# Altium schematic sheet 6.232-485-CAN-DB.SchDoc(6.232-485-CAN-DB)
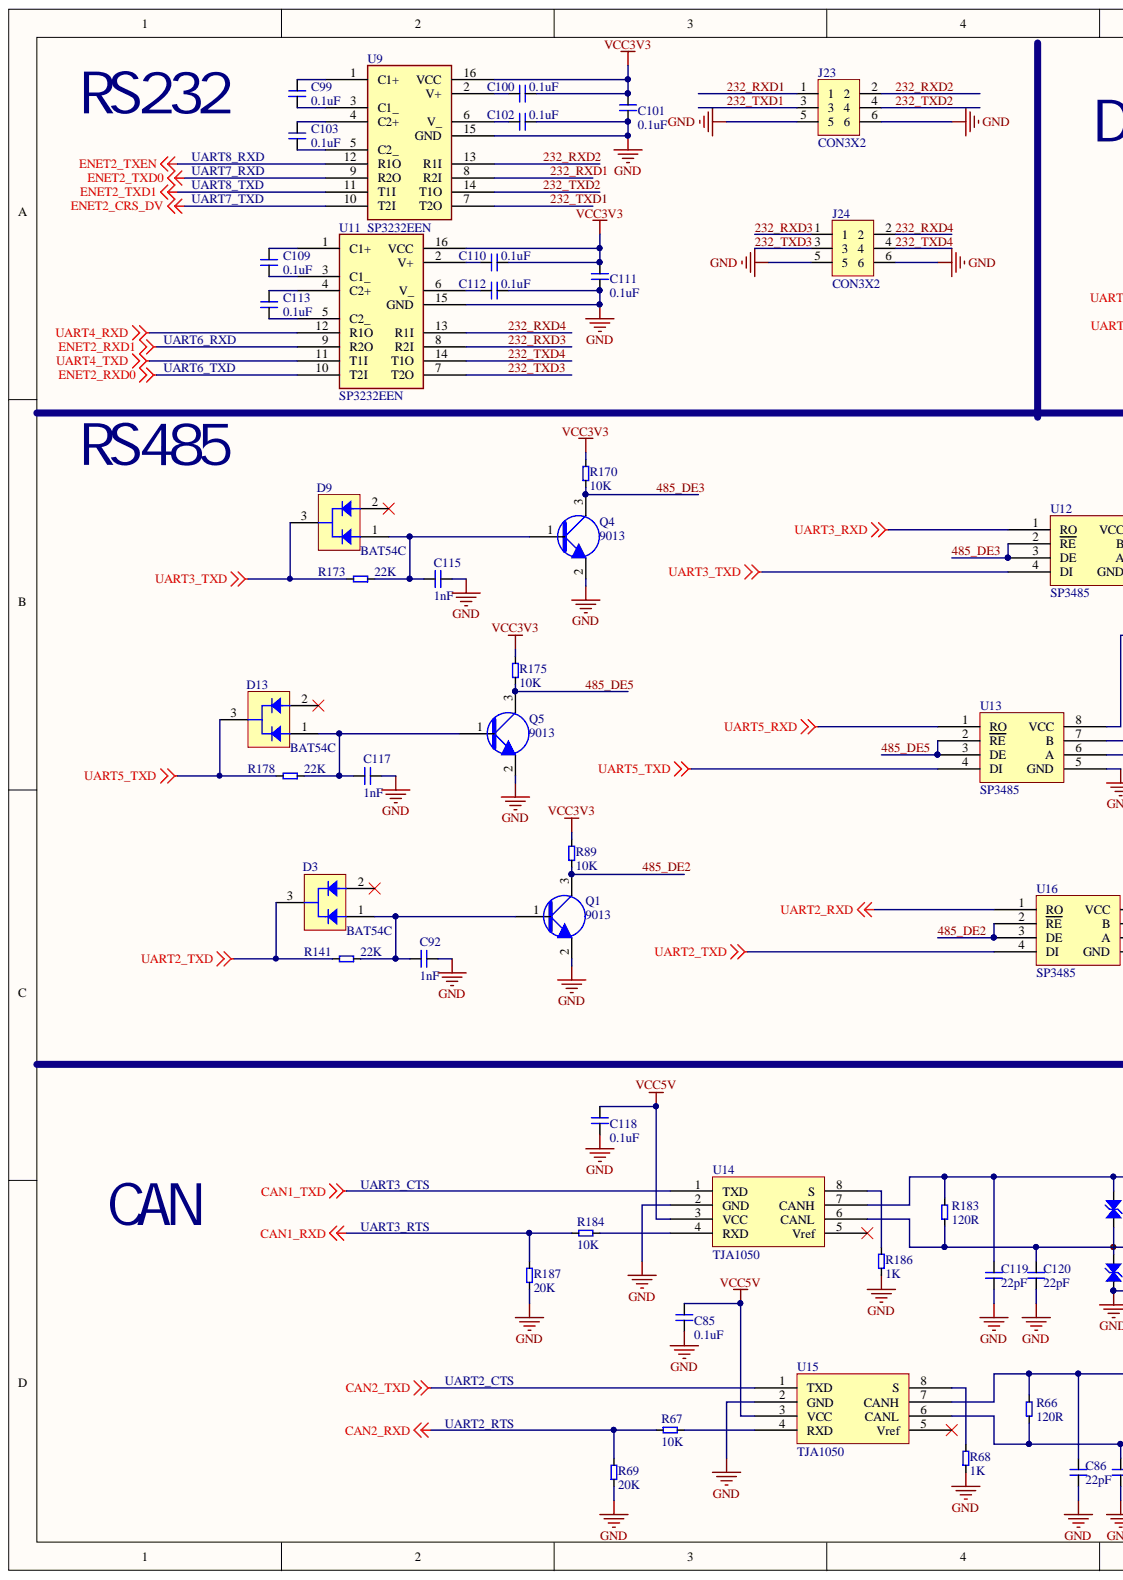
[diagram p0: 6.232-485-CAN-DB.SchDoc, left]
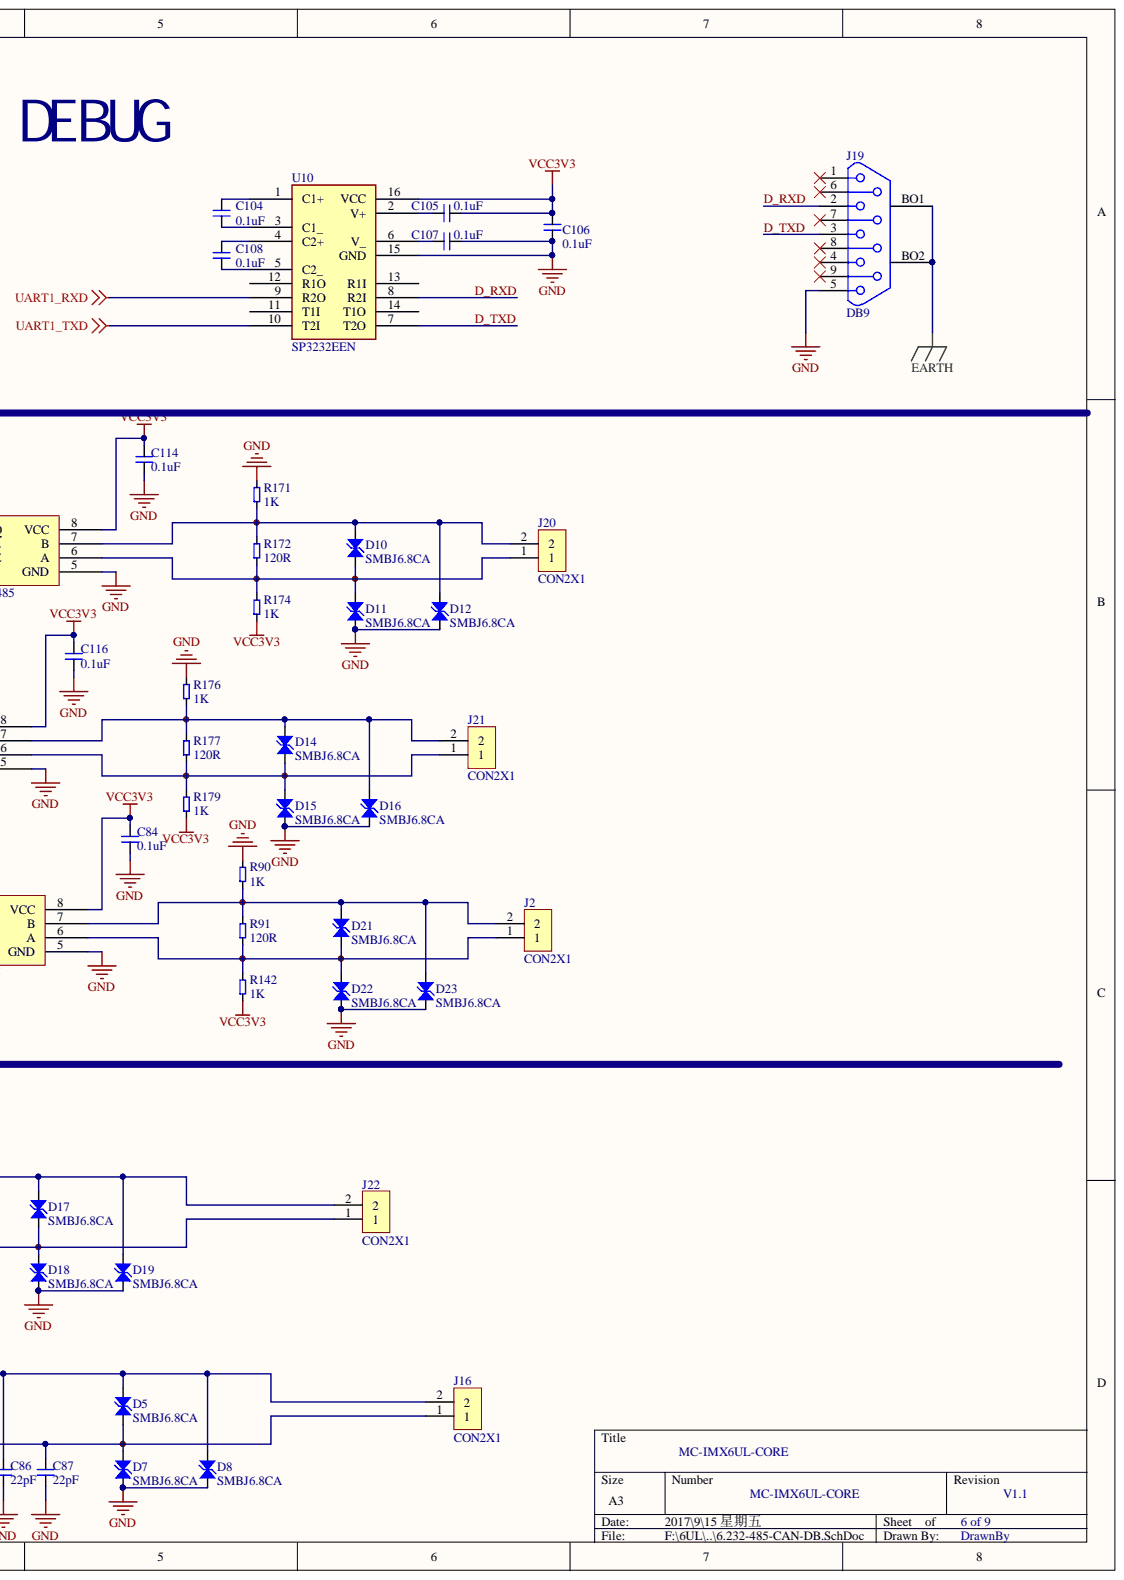
[diagram p1: 6.232-485-CAN-DB.SchDoc, right]

## Parts
C84  0.1uF  [0603C]
C85  0.1uF  [0603C]
C86  22pF  [0603C]
C87  22pF  [0603C]
C92  1nF  [0603C]
C99  0.1uF  [0603C]
C100  0.1uF  [0603C]
C101  0.1uF  [0603C]
C102  0.1uF  [0603C]
C103  0.1uF  [0603C]
C104  0.1uF  [0603C]
C105  0.1uF  [0603C]
C106  0.1uF  [0603C]
C107  0.1uF  [0603C]
C108  0.1uF  [0603C]
C109  0.1uF  [0603C]
C110  0.1uF  [0603C]
C111  0.1uF  [0603C]
C112  0.1uF  [0603C]
C113  0.1uF  [0603C]
C114  0.1uF  [0603C]
C115  1nF  [0603C]
C116  0.1uF  [0603C]
C117  1nF  [0603C]
C118  0.1uF  [0603C]
C119  22pF  [0603C]
C120  22pF  [0603C]
D3  BAT54C  [SOT-23]
D5  SMBJ6.8CA  [SMBJ]
D7  SMBJ6.8CA  [SMBJ]
D8  SMBJ6.8CA  [SMBJ]
D9  BAT54C  [SOT-23]
D10  SMBJ6.8CA  [SMBJ]
D11  SMBJ6.8CA  [SMBJ]
D12  SMBJ6.8CA  [SMBJ]
D13  BAT54C  [SOT-23]
D14  SMBJ6.8CA  [SMBJ]
D15  SMBJ6.8CA  [SMBJ]
D16  SMBJ6.8CA  [SMBJ]
D17  SMBJ6.8CA  [SMBJ]
D18  SMBJ6.8CA  [SMBJ]
D19  SMBJ6.8CA  [SMBJ]
D21  SMBJ6.8CA  [SMBJ]
D22  SMBJ6.8CA  [SMBJ]
D23  SMBJ6.8CA  [SMBJ]
J2  CON2X1  [IDC2X1 3.81]
J16  CON2X1  [IDC2X1 3.81]
J19  DB9  [DB-9/M]
J20  CON2X1  [IDC2X1 3.81]
J21  CON2X1  [IDC2X1 3.81]
J22  CON2X1  [IDC2X1 3.81]
J23  CON3X2  [IDC3X2-2.54MM]
J24  CON3X2  [IDC3X2-2.54MM]
Q1  9013  [SOT-23]
Q4  9013  [SOT-23]
Q5  9013  [SOT-23]
R66  120R  [0603R]
R67  10K  [0603R]
R68  1K  [0603R]
R69  20K  [0603R]
R89  10K  [0603R]
R90  1K  [0603R]
R91  120R  [0603R]
R141  22K  [0603R]
R142  1K  [0603R]
R170  10K  [0603R]
R171  1K  [0603R]
R172  120R  [0603R]
R173  22K  [0603R]
R174  1K  [0603R]
R175  10K  [0603R]
R176  1K  [0603R]
R177  120R  [0603R]
R178  22K  [0603R]
R179  1K  [0603R]
R183  120R  [0603R]
R184  10K  [0603R]
R186  1K  [0603R]
R187  20K  [0603R]
U9  SP3232EEN  [SOIC16-1.27]
U10  SP3232EEN  [SOIC16-1.27]
U11  SP3232EEN  [SOIC16-1.27]
U12  SP3485  [SOP8-1.27]
U13  SP3485  [SOP8-1.27]
U14  TJA1050  [SOP8-1.27]
U15  TJA1050  [SOP8-1.27]
U16  SP3485  [SOP8-1.27]

## Nets
CAN1_RXD: R184.1 R187.2
CAN1_TXD: U14.1(TXD)
CAN2_RXD: R67.1 R69.2
CAN2_TXD: U15.1(TXD)
EARTH: J19.BO1 J19.BO2
ENET2_CRS_DV: U9.10(T2I)
ENET2_RXD0: U11.10(T2I)
ENET2_RXD1: U11.9(R2O)
ENET2_TXD0: U9.9(R2O)
ENET2_TXD1: U9.11(T1I)
ENET2_TXEN: U9.12(R1O)
GND: C84.2 C85.2 C86.1 C87.1 C92.1 C101.2 C102.2 C106.2 C107.2 C111.2 C112.2 C114.2 C115.1 C116.2 C117.1 C118.2 C119.1 C120.1 D7.1 D8.1 D11.1 D12.1 D15.1 D16.1 D18.1 D19.1 D22.1 D23.1 J19.5 J23.5 J23.6 J24.5 J24.6 Q1.2 Q4.2 Q5.2 R68.1 R69.1 R90.2 R171.2 R176.2 R186.1 R187.1 U9.15(GND) U10.15(GND) U11.15(GND) U12.5(GND) U13.5(GND) U14.2(GND) U15.2(GND) U16.5(GND)
UART1_RXD: U10.9(R2O)
UART1_TXD: U10.10(T2I)
UART2_RXD: U16.1(RO)
UART2_TXD: D3.3 R141.2 U16.4(DI)
UART3_RXD: U12.1(RO)
UART3_TXD: D9.3 R173.2 U12.4(DI)
UART4_RXD: U11.12(R1O)
UART4_TXD: U11.11(T1I)
UART5_RXD: U13.1(RO)
UART5_TXD: D13.3 R178.2 U13.4(DI)
VCC3V3: C84.1 C100.2 C101.1 C105.2 C106.1 C110.2 C111.1 C114.1 C116.1 R89.2 R142.1 R170.2 R174.1 R175.2 R179.1 U9.16(VCC) U10.16(VCC) U11.16(VCC) U12.8(VCC) U13.8(VCC) U16.8(VCC)
VCC5V: C85.1 C118.1 U14.3(VCC) U15.3(VCC)
232_RXD1: J23.1 U9.8(R2I)
232_RXD2: J23.2 U9.13(R1I)
232_RXD3: J24.1 U11.8(R2I)
232_RXD4: J24.2 U11.13(R1I)
232_TXD1: J23.3 U9.7(T2O)
232_TXD2: J23.4 U9.14(T1O)
232_TXD3: J24.3 U11.7(T2O)
232_TXD4: J24.4 U11.14(T1O)
485_DE2: Q1.3 R89.1 U16.2(RE) U16.3(DE)
485_DE3: Q4.3 R170.1 U12.2(RE) U12.3(DE)
485_DE5: Q5.3 R175.1 U13.2(RE) U13.3(DE)
D_RXD: J19.2 U10.8(R2I)
D_TXD: J19.3 U10.7(T2O)
NetC86_2: C86.2 D5.2 D8.2 J16.2 R66.2 U15.7(CANH)
NetC87_2: C87.2 D5.1 D7.2 J16.1 R66.1 U15.6(CANL)
NetC92_2: C92.2 D3.1 Q1.1 R141.1
NetC99_1: C99.1 U9.1(C1+)
NetC99_2: C99.2 U9.3(C1_)
NetC100_1: C100.1 U9.2(V+)
NetC102_1: C102.1 U9.6(V_)
NetC103_1: C103.1 U9.4(C2+)
NetC103_2: C103.2 U9.5(C2_)
NetC104_1: C104.1 U10.1(C1+)
NetC104_2: C104.2 U10.3(C1_)
NetC105_1: C105.1 U10.2(V+)
NetC107_1: C107.1 U10.6(V_)
NetC108_1: C108.1 U10.4(C2+)
NetC108_2: C108.2 U10.5(C2_)
NetC109_1: C109.1 U11.1(C1+)
NetC109_2: C109.2 U11.3(C1_)
NetC110_1: C110.1 U11.2(V+)
NetC112_1: C112.1 U11.6(V_)
NetC113_1: C113.1 U11.4(C2+)
NetC113_2: C113.2 U11.5(C2_)
NetC115_2: C115.2 D9.1 Q4.1 R173.1
NetC117_2: C117.2 D13.1 Q5.1 R178.1
NetC119_2: C119.2 D17.2 D19.2 J22.2 R183.2 U14.7(CANH)
NetC120_2: C120.2 D17.1 D18.2 J22.1 R183.1 U14.6(CANL)
NetD10_1: D10.1 D11.2 J20.1 R172.1 R174.2 U12.6(A)
NetD10_2: D10.2 D12.2 J20.2 R171.1 R172.2 U12.7(B)
NetD14_1: D14.1 D15.2 J21.1 R177.1 R179.2 U13.6(A)
NetD14_2: D14.2 D16.2 J21.2 R176.1 R177.2 U13.7(B)
NetD21_1: D21.1 D22.2 J2.1 R91.1 R142.2 U16.6(A)
NetD21_2: D21.2 D23.2 J2.2 R90.1 R91.2 U16.7(B)
NetR67_2: R67.2 U15.4(RXD)
NetR68_2: R68.2 U15.8(S)
NetR184_2: R184.2 U14.4(RXD)
NetR186_2: R186.2 U14.8(S)

Not connected: D3 2; D9 2; D13 2; J19 1 4 6 7 8 9; U10 11(T1I) 12(R1O) 13(R1I) 14(T1O); U14 5(Vref); U15 5(Vref)

## Library data of the parts
C84-C87 C92 C99-C120 = 电容: Component Kind: Standard; Description: 电容
  C84 C85 C99-C114 C116 C118: Library Name: LDT-SCH.SCHLIB
D3 D9 D13 = BAT54C: SCHOTTKY DIODE; Component Kind: Standard; Library Name: LDT-SCH.SCHLIB
D5 D7 D8 D10-D12 D14-D19 D21-D23 = P6KE: Component Kind: Standard; Library Name: LDT-SCH.SCHLIB
J2 J16 J20-J22 = Component_1: Component Kind: Standard; Library Name: Schlib1.SchLib
R66-R69 R89-R91 R141 R142 R170-R179 R183 R184 R186 R187 = 电阻: Component Kind: Standard; Description: 电阻
  R66-R69 R90 R91 R142 R171 R172 R174 R176 R177 R179 R183 R184 R186 R187: Library Name: LDT-SCH.SCHLIB
U9-U11 = MAX232ESE: Text Field1: MAXIM; Text Field2: RS232; Component Kind: Standard; Library Name: LDT-SCH.SCHLIB
U12 U13 U16 = MAX485ESA: Component Kind: Standard; Library Name: LDT-SCH.SCHLIB
J19 = DB9: Component Kind: Standard; Library Name: LDT-SCH.SCHLIB
J23 J24 = CON3X2: Component Kind: Standard; Library Name: Schlib1.SchLib
Q1 Q4 Q5 = 9013: Component Kind: Standard; Library Name: LDT-SCH.SCHLIB
U14 U15 = TJA1050: Component Kind: Standard; Library Name: LDT-SCH.SCHLIB
